# Altium schematic sheet STM32_F4VX_M.SchDoc(STM32_F4VX_M)
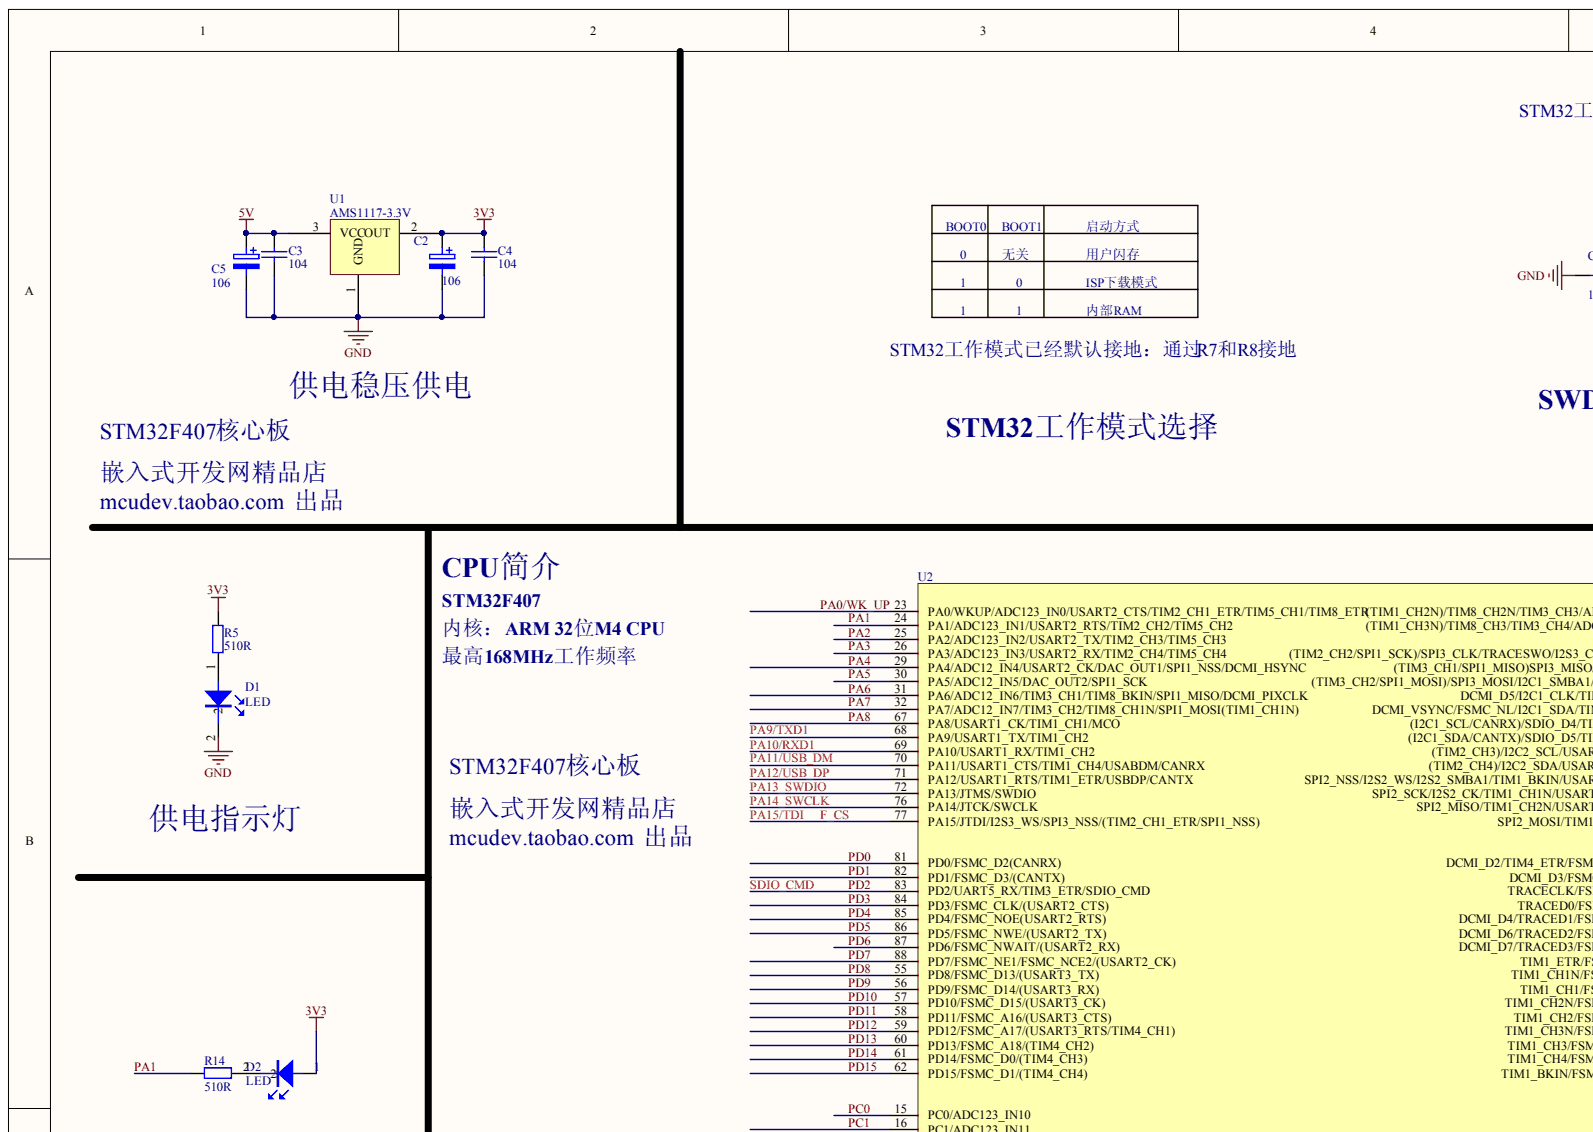
[diagram p0: STM32_F4VX_M.SchDoc, top left]
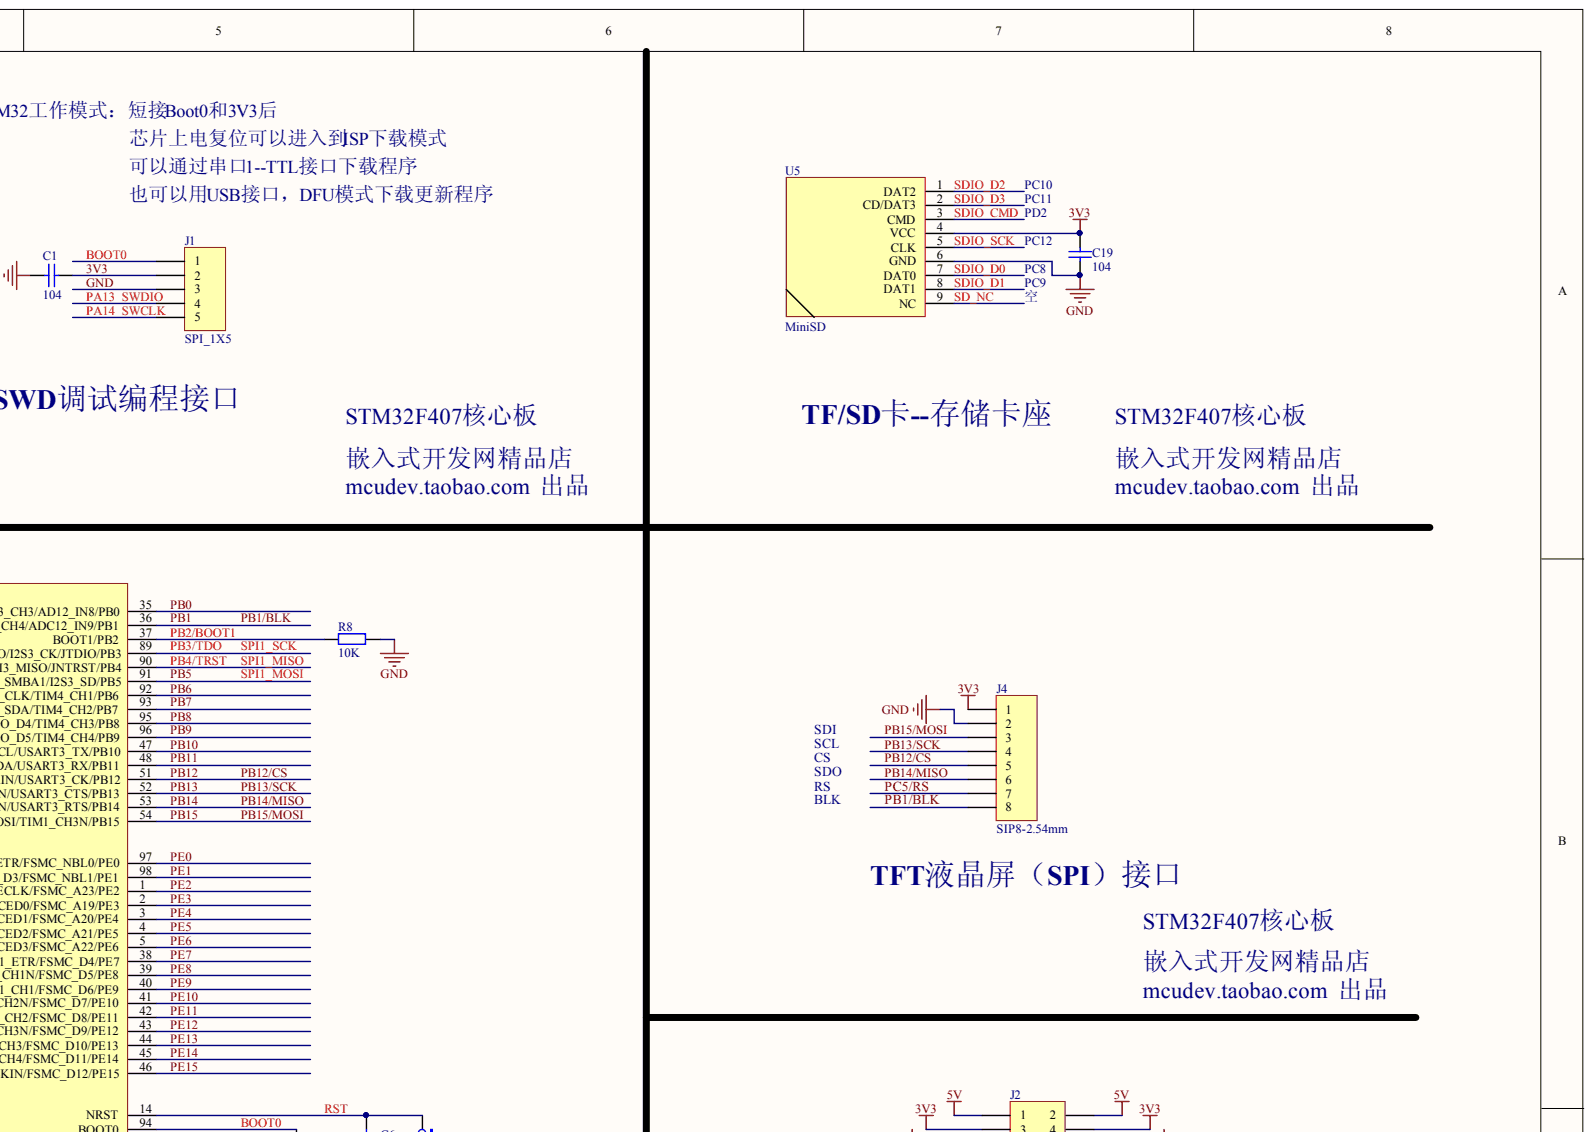
[diagram p1: STM32_F4VX_M.SchDoc, top right]
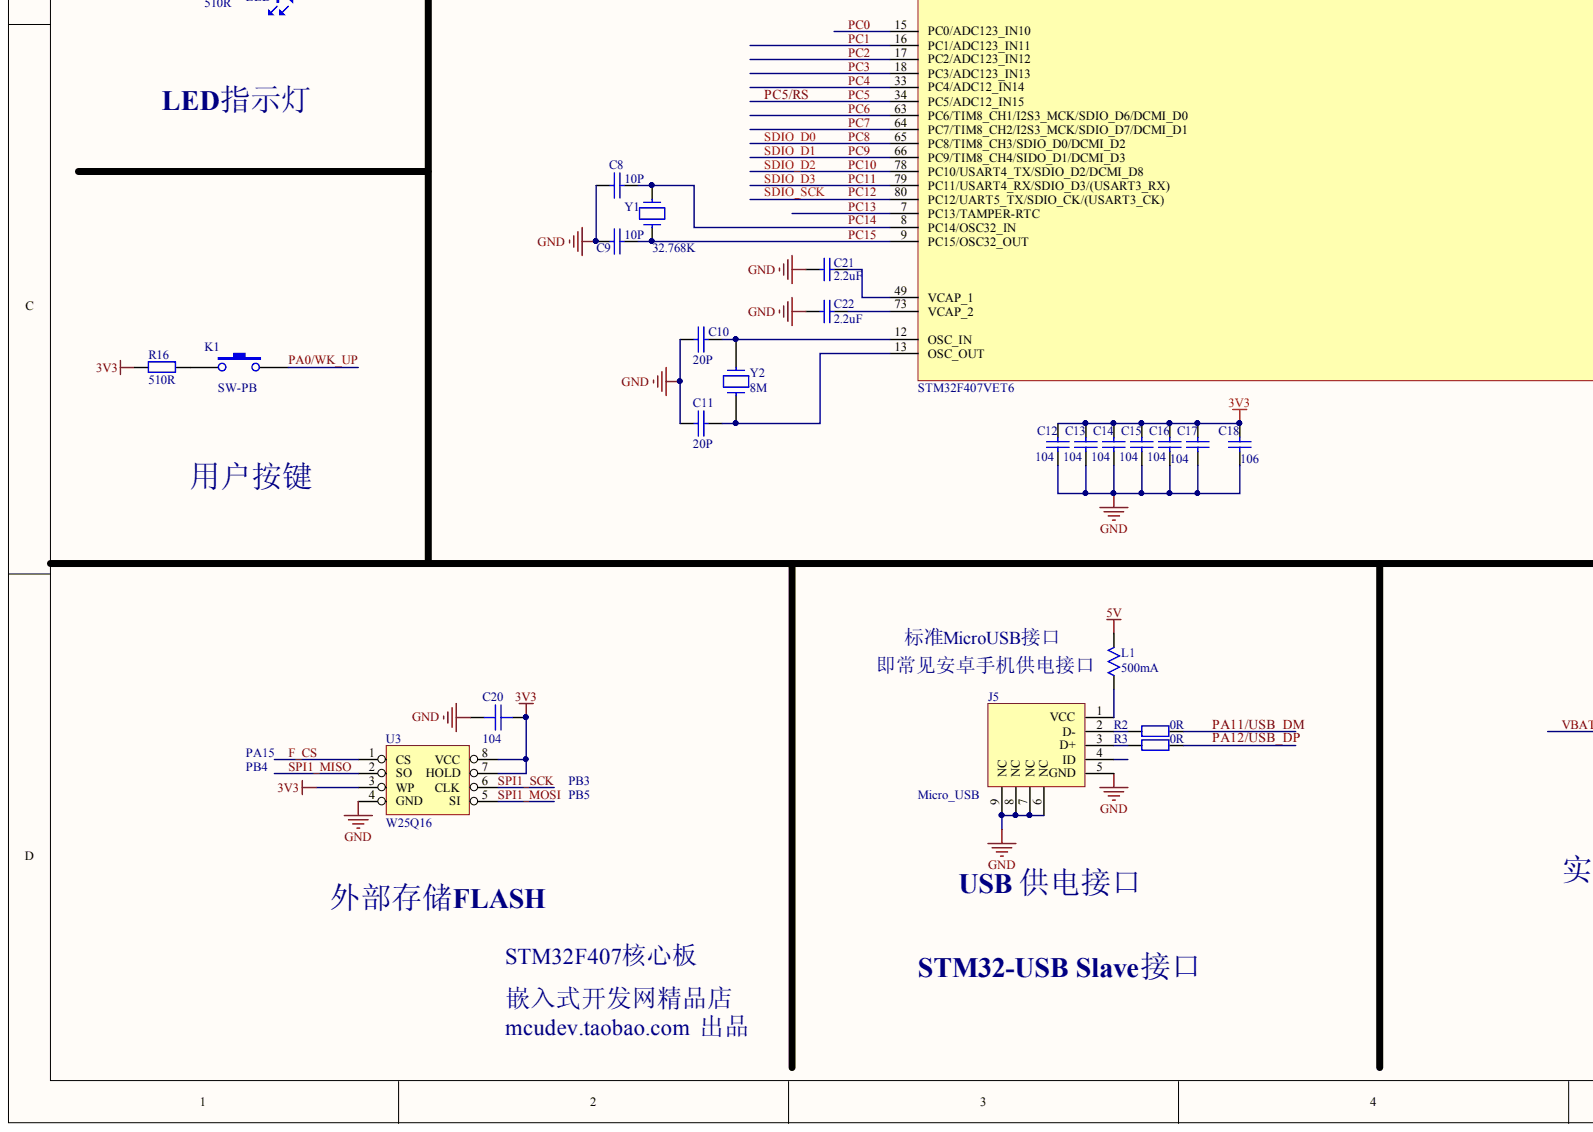
[diagram p2: STM32_F4VX_M.SchDoc, bottom left]
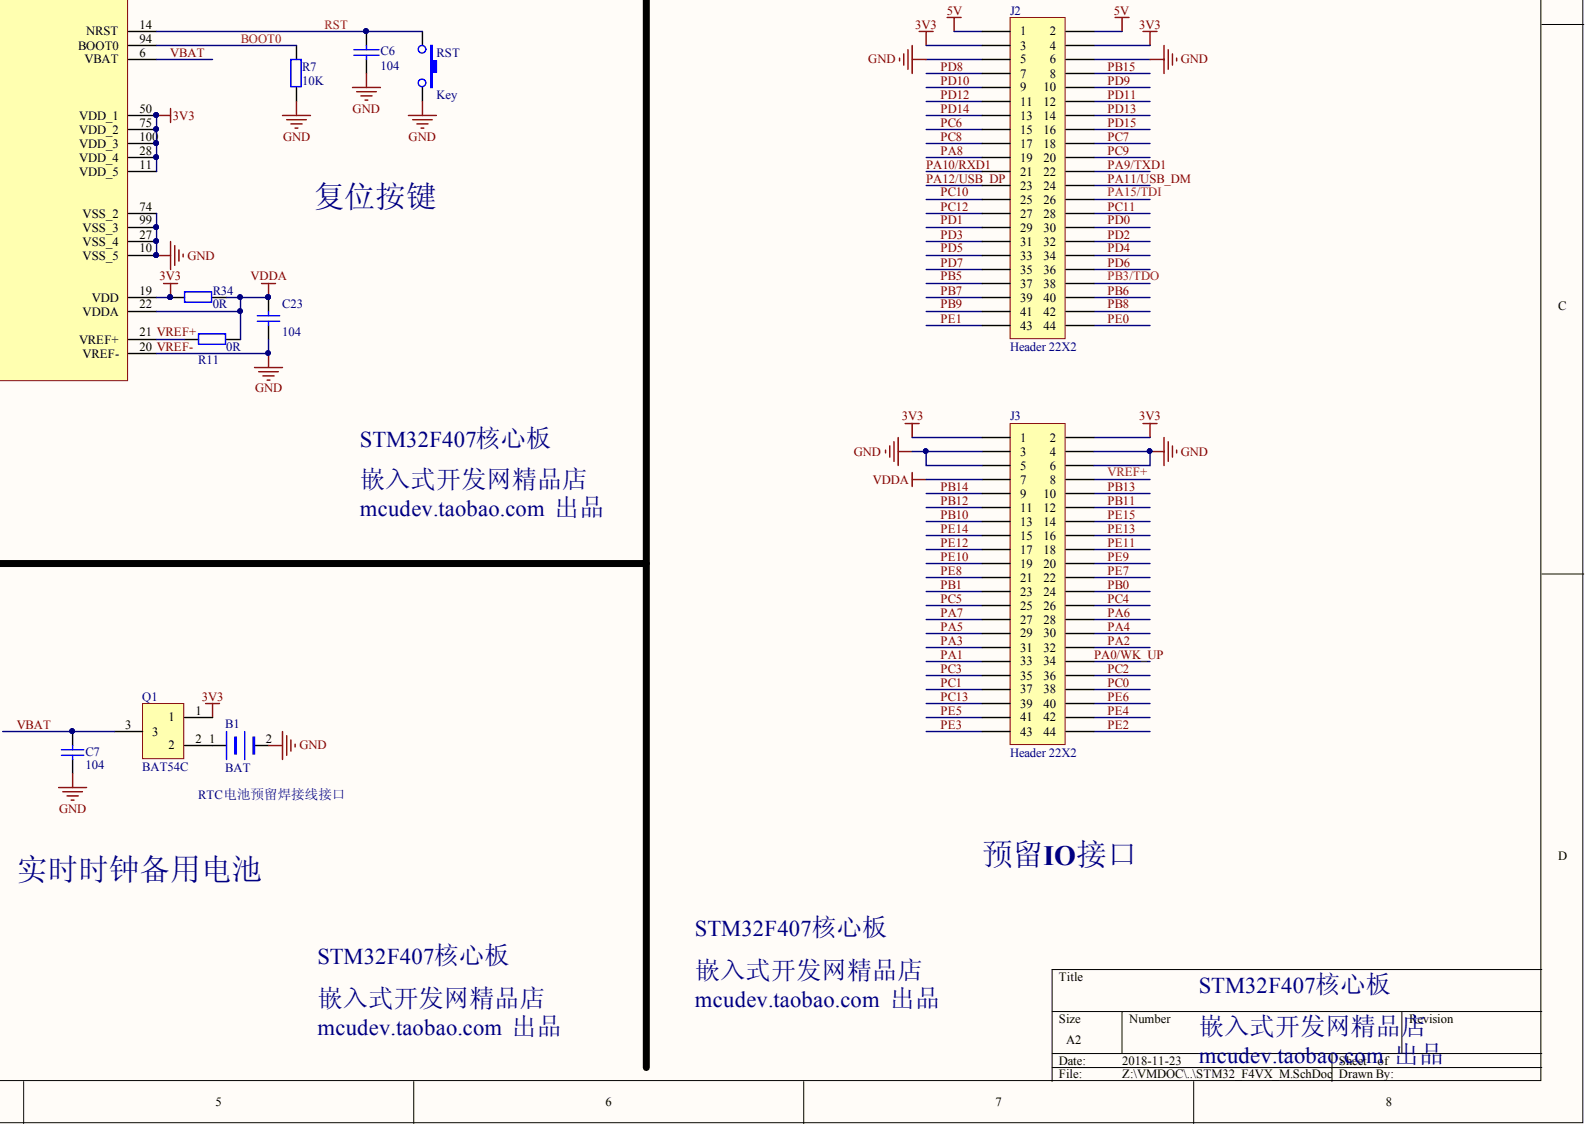
[diagram p3: STM32_F4VX_M.SchDoc, bottom right]

## Parts
B1  BAT  [SIP2-2.54mm]
C1  104  [RC0402]
C2  106  [RC0805]
C3  104  [RC0402]
C4  104  [RC0402]
C5  106  [RC0805]
C6  104  [RC0402]
C7  104  [RC0402]
C8  10P  [RC0402]
C9  10P  [RC0402]
C10  20P  [RC0402]
C11  20P  [RC0402]
C12  104  [RC0402]
C13  104  [RC0402]
C14  104  [RC0402]
C15  104  [RC0402]
C16  104  [RC0402]
C17  104  [RC0402]
C18  106  [RC0805]
C19  104  [RC0402]
C20  104  [RC0402]
C21  2.2uF  [RC0603]
C22  2.2uF  [RC0603]
C23  104  [RC0402]
D1  LED  [LED-0603]
D2  LED  [LED-0603]
J1  SPI_1X5  [SIP5-2.54mm]
J2  Header 22X2  [IDE2X22]
J3  Header 22X2  [IDE2X22]
J4  SIP8-2.54mm  [HDR1X8]
J5  Micro_USB  [micro_USB]
K1  SW-PB  [SW-SPST]
L1  500mA  [RC0805]
Q1  BAT54C  [SOT-23-BAT54C]
R2  0R  [RC0402]
R3  0R  [RC0402]
R5  510R  [RC0402]
R7  10K  [RC0402]
R8  10K  [RC0402]
R11  0R  [RC0402]
R14  510R  [RC0402]
R16  510R  [RC0402]
R34  0R  [RC0402]
RST  Key  [SW-SPST]
U1  AMS1117-3.3V  [SOT-223]
U2  STM32F407VET6
U3  W25Q16  [SOP8L]
U5  MiniSD  [TF_SD]
Y1  32.768K  [JZ3215-32K]
Y2  8M  [JZ5032-8M]

## Nets
5V: C3.1 C5.1 J2.1 J2.2 L1.2 U1.3
VDDA: C23.1 J3.7 R11.2 R34.2 U2.22(VDDA)
3V3: C1.2 C2.1 C4.1 C12.1 C13.1 C14.1 C15.1 C16.1 C17.1 C18.1 C19.1 C20.2 D2.1 J1.2 J2.3 J2.4 J3.1 J3.2 J4.1 Q1.1 R5.2 R16.2 R34.1 U1.2 U2.11(VDD_5) U2.19(VDD) U2.28(VDD_4) U2.50(VDD_1) U2.75(VDD_2) U2.100(VDD_3) U3.3(WP) U3.7(HOLD) U3.8(VCC) U5.4(VCC)
BOOT0: J1.1 R7.2 U2.94(BOOT0)
F_CS: J2.26 U2.77(PA15/JTDI/I2S3_WS/SPI3_NSS/(TIM2_CH1_ETR/SPI1_NSS)) U3.1(CS)
GND: B1.2 C1.1 C2.2 C3.2 C4.2 C5.2 C6.1 C7.1 C8.1 C9.1 C10.1 C11.2 C12.2 C13.2 C14.2 C15.2 C16.2 C17.2 C18.2 C19.2 C20.1 C21.1 C22.1 C23.2 D1.2 J1.3 J2.5 J2.6 J3.3 J3.4 J3.5 J3.6 J4.2 J5.5(GND) J5.6(NC) J5.7(NC) J5.8(NC) J5.9(NC) R7.1 R8.1 RST.1 U1.1 U2.10(VSS_5) U2.20(VREF-) U2.27(VSS_4) U2.74(VSS_2) U2.99(VSS_3) U3.4(GND) U5.6(GND)
PA0/WK_UP: J3.34 K1.2 U2.23(PA0/WKUP/ADC123_IN0/USART2_CTS/TIM2_CH1_ETR/TIM5_CH1/TIM8_ETR)
PA1: J3.33 R14.1 U2.24(PA1/ADC123_IN1/USART2_RTS/TIM2_CH2/TIM5_CH2)
PA2: J3.32 U2.25(PA2/ADC123_IN2/USART2_TX/TIM2_CH3/TIM5_CH3)
PA3: J3.31 U2.26(PA3/ADC123_IN3/USART2_RX/TIM2_CH4/TIM5_CH4)
PA4: J3.30 U2.29(PA4/ADC12_IN4/USART2_CK/DAC_OUT1/SPI1_NSS/DCMI_HSYNC)
PA5: J3.29 U2.30(PA5/ADC12_IN5/DAC_OUT2/SPI1_SCK)
PA6: J3.28 U2.31(PA6/ADC12_IN6/TIM3_CH1/TIM8_BKIN/SPI1_MISO/DCMI_PIXCLK)
PA7: J3.27 U2.32(PA7/ADC12_IN7/TIM3_CH2/TIM8_CH1N/SPI1_MOSI(TIM1_CH1N))
PA8: J2.19 U2.67(PA8/USART1_CK/TIM1_CH1/MCO)
PA9/TXD1: J2.22 U2.68(PA9/USART1_TX/TIM1_CH2)
PA10/RXD1: J2.21 U2.69(PA10/USART1_RX/TIM1_CH2)
PA11/USB_DM: J2.24 R2.1 U2.70(PA11/USART1_CTS/TIM1_CH4/USABDM/CANRX)
PA12/USB_DP: J2.23 R3.1 U2.71(PA12/USART1_RTS/TIM1_ETR/USBDP/CANTX)
PA13_SWDIO: J1.4 U2.72(PA13/JTMS/SWDIO)
PA14_SWCLK: J1.5 U2.76(PA14/JTCK/SWCLK)
PB0: J3.24 U2.35((TIM1_CH2N)/TIM8_CH2N/TIM3_CH3/AD12_IN8/PB0)
PB1: J3.23 J4.8 U2.36((TIM1_CH3N)/TIM8_CH3/TIM3_CH4/ADC12_IN9/PB1)
PB2/BOOT1: R8.2 U2.37(BOOT1/PB2)
PB3/TDO: J2.38 U2.89((TIM2_CH2/SPI1_SCK)/SPI3_CLK/TRACESWO/I2S3_CK/JTDIO/PB3) U3.6(CLK)
PB4/TRST: U2.90((TIM3_CH1/SPI1_MISO)SPI3_MISO/JNTRST/PB4) U3.2(SO)
PB5: J2.37 U2.91((TIM3_CH2/SPI1_MOSI)/SPI3_MOSI/I2C1_SMBA1/I2S3_SD/PB5) U3.5(SI)
PB6: J2.40 U2.92(DCMI_D5/I2C1_CLK/TIM4_CH1/PB6)
PB7: J2.39 U2.93(DCMI_VSYNC/FSMC_NL/I2C1_SDA/TIM4_CH2/PB7)
PB8: J2.42 U2.95((I2C1_SCL/CANRX)/SDIO_D4/TIM4_CH3/PB8)
PB9: J2.41 U2.96((I2C1_SDA/CANTX)/SDIO_D5/TIM4_CH4/PB9)
PB10: J3.13 U2.47((TIM2_CH3)/I2C2_SCL/USART3_TX/PB10)
PB11: J3.12 U2.48((TIM2_CH4)/I2C2_SDA/USART3_RX/PB11)
PB12: J3.11 J4.5 U2.51(SPI2_NSS/I2S2_WS/I2S2_SMBA1/TIM1_BKIN/USART3_CK/PB12)
PB13: J3.10 J4.4 U2.52(SPI2_SCK/I2S2_CK/TIM1_CH1N/USART3_CTS/PB13)
PB14: J3.9 J4.6 U2.53(SPI2_MISO/TIM1_CH2N/USART3_RTS/PB14)
PB15: J2.8 J4.3 U2.54(SPI2_MOSI/TIM1_CH3N/PB15)
PC0: J3.38 U2.15(PC0/ADC123_IN10)
PC1: J3.37 U2.16(PC1/ADC123_IN11)
PC2: J3.36 U2.17(PC2/ADC123_IN12)
PC3: J3.35 U2.18(PC3/ADC123_IN13)
PC4: J3.26 U2.33(PC4/ADC12_IN14)
PC5: J3.25 J4.7 U2.34(PC5/ADC12_IN15)
PC6: J2.15 U2.63(PC6/TIM8_CH1/I2S3_MCK/SDIO_D6/DCMI_D0)
PC7: J2.18 U2.64(PC7/TIM8_CH2/I2S3_MCK/SDIO_D7/DCMI_D1)
PC8: J2.17 U2.65(PC8/TIM8_CH3/SDIO_D0/DCMI_D2) U5.7(DAT0)
PC9: J2.20 U2.66(PC9/TIM8_CH4/SIDO_D1/DCMI_D3) U5.8(DAT1)
PC10: J2.25 U2.78(PC10/USART4_TX/SDIO_D2/DCMI_D8) U5.1(DAT2)
PC11: J2.28 U2.79(PC11/USART4_RX/SDIO_D3/(USART3_RX)) U5.2(CD/DAT3)
PC12: J2.27 U2.80(PC12/UART5_TX/SDIO_CK/(USART3_CK)) U5.5(CLK)
PC13: J3.39 U2.7(PC13/TAMPER-RTC)
PC14: C8.2 U2.8(PC14/OSC32_IN) Y1.2
PC15: C9.2 U2.9(PC15/OSC32_OUT) Y1.1
PD0: J2.30 U2.81(PD0/FSMC_D2(CANRX))
PD1: J2.29 U2.82(PD1/FSMC_D3/(CANTX))
PD2: J2.32 U2.83(PD2/UART5_RX/TIM3_ETR/SDIO_CMD) U5.3(CMD)
PD3: J2.31 U2.84(PD3/FSMC_CLK/(USART2_CTS))
PD4: J2.34 U2.85(PD4/FSMC_NOE(USART2_RTS))
PD5: J2.33 U2.86(PD5/FSMC_NWE/(USART2_TX))
PD6: J2.36 U2.87(PD6/FSMC_NWAIT/(USART2_RX))
PD7: J2.35 U2.88(PD7/FSMC_NE1/FSMC_NCE2/(USART2_CK))
PD8: J2.7 U2.55(PD8/FSMC_D13/(USART3_TX))
PD9: J2.10 U2.56(PD9/FSMC_D14/(USART3_RX))
PD10: J2.9 U2.57(PD10/FSMC_D15/(USART3_CK))
PD11: J2.12 U2.58(PD11/FSMC_A16/(USART3_CTS))
PD12: J2.11 U2.59(PD12/FSMC_A17/(USART3_RTS/TIM4_CH1))
PD13: J2.14 U2.60(PD13/FSMC_A18/(TIM4_CH2))
PD14: J2.13 U2.61(PD14/FSMC_D0/(TIM4_CH3))
PD15: J2.16 U2.62(PD15/FSMC_D1/(TIM4_CH4))
PE0: J2.44 U2.97(DCMI_D2/TIM4_ETR/FSMC_NBL0/PE0)
PE1: J2.43 U2.98(DCMI_D3/FSMC_NBL1/PE1)
PE2: J3.44 U2.1(TRACECLK/FSMC_A23/PE2)
PE3: J3.43 U2.2(TRACED0/FSMC_A19/PE3)
PE4: J3.42 U2.3(DCMI_D4/TRACED1/FSMC_A20/PE4)
PE5: J3.41 U2.4(DCMI_D6/TRACED2/FSMC_A21/PE5)
PE6: J3.40 U2.5(DCMI_D7/TRACED3/FSMC_A22/PE6)
PE7: J3.22 U2.38(TIM1_ETR/FSMC_D4/PE7)
PE8: J3.21 U2.39(TIM1_CH1N/FSMC_D5/PE8)
PE9: J3.20 U2.40(TIM1_CH1/FSMC_D6/PE9)
PE10: J3.19 U2.41(TIM1_CH2N/FSMC_D7/PE10)
PE11: J3.18 U2.42(TIM1_CH2/FSMC_D8/PE11)
PE12: J3.17 U2.43(TIM1_CH3N/FSMC_D9/PE12)
PE13: J3.16 U2.44(TIM1_CH3/FSMC_D10/PE13)
PE14: J3.15 U2.45(TIM1_CH4/FSMC_D11/PE14)
PE15: J3.14 U2.46(TIM1_BKIN/FSMC_D12/PE15)
RST: C6.2 RST.2 U2.14(NRST)
SD_NC: U5.9(NC)
VBAT: C7.2 Q1.3 U2.6(VBAT)
VREF+: J3.8 R11.1 U2.21(VREF+)
NetB1_1: B1.1 Q1.2
NetC10_2: C10.2 U2.12(OSC_IN) Y2.1
NetC11_1: C11.1 U2.13(OSC_OUT) Y2.2
NetC21_2: C21.2 U2.49(VCAP_1)
NetC22_2: C22.2 U2.73(VCAP_2)
NetD1_1: D1.1 R5.1
NetD2_2: D2.2 R14.2
NetJ5_1: J5.1(VCC) L1.1
NetJ5_2: J5.2(D-) R2.2
NetJ5_3: J5.3(D+) R3.2
NetK1_1: K1.1 R16.1

Not connected: J5 4(ID)

## Library data of the parts
B1 = BATTERY: Component Kind: Standard
C1 C7 C12-C18 C21-C23 = Cap: Capacitor; Component Kind: Standard; LatestRevisionDate: 17-Jul-2002; LatestRevisionNote: Re-released for DXP Platform.; PackageReference: RAD-0.3; Published: 8-Jun-2000; Publisher: Altium Limited
C2 C5 = ELECTRO2: Component Kind: Standard
C3 C4 C6 C10 C11 = CAP: Capacitor; Component Kind: Standard
C8 C9 C20 = CAP: Component Kind: Standard
  C20: Library Name: YT1_SCH_99SE.SCHLIB
C19 = Cap Semi: Capacitor (Semiconductor SIM Model); Component Kind: Standard; Published: 8-Jun-2000; Revision: July-2002: Re-released for DXP Platform.; Publisher: Altium Limited
J1 = Header 5H: Header, 5-Pin, Right Angle; Component Kind: Standard; LatestRevisionDate: 17-Jul-2002; LatestRevisionNote: Re-released for DXP Platform.; Library Name: Miscellaneous Connectors.IntLib; Signal Integrity: Connector; Publisher: Altium Limited
J2 J3 = Header 22X2: Header, 22-Pin, Dual row; Component Kind: Standard; LatestRevisionDate: 17-Jul-2002; LatestRevisionNote: Re-released for DXP Platform.; Library Name: Miscellaneous Connectors.IntLib; Signal Integrity: Connector; Publisher: Altium Limited
J4 = Header 8: Header, 8-Pin; Component Kind: Standard; LatestRevisionDate: 17-Jul-2002; LatestRevisionNote: Re-released for DXP Platform.; Library Name: Miscellaneous Connectors.IntLib; Signal Integrity: Connector; Publisher: Altium Limited
J5 = MiniUSB: Component Kind: Standard; Library Name: Air_Guard_A1.SchLib
L1 = RES: Component Kind: Standard; Library Name: YT1_SCH_99SE.SCHLIB
R2 R3 R5 R7 R8 R11 R14 R16 R34 = Res2: Resistor; Component Kind: Standard; Library Name: Miscellaneous Devices.IntLib
RST = SW-PB: Component Kind: Standard
U1 = 11: Component Kind: Standard
U3 = W25X16: Component Kind: Standard; Library Name: YT1_SCH_99SE.SCHLIB
Y1 = CRYSTAL: 晶振; Component Kind: Standard
Y2 = CRYSTAL: Component Kind: Standard; Description: Crystal
D1 D2 = LED: Component Kind: Standard
K1 = SW-PB: Component Kind: Standard
Q1 = BAT54C: Component Kind: Standard; Library Name: Newcapec9263.SchLib
U2 = STM32F407VET6: Component Kind: Standard; Library Name: STM32_F4VE.SchLib
U5 = MiniSD: Component Kind: Standard; Library Name: STM32_RF.SchLib
